# Altium schematic sheet ESP32-C3.SchDoc
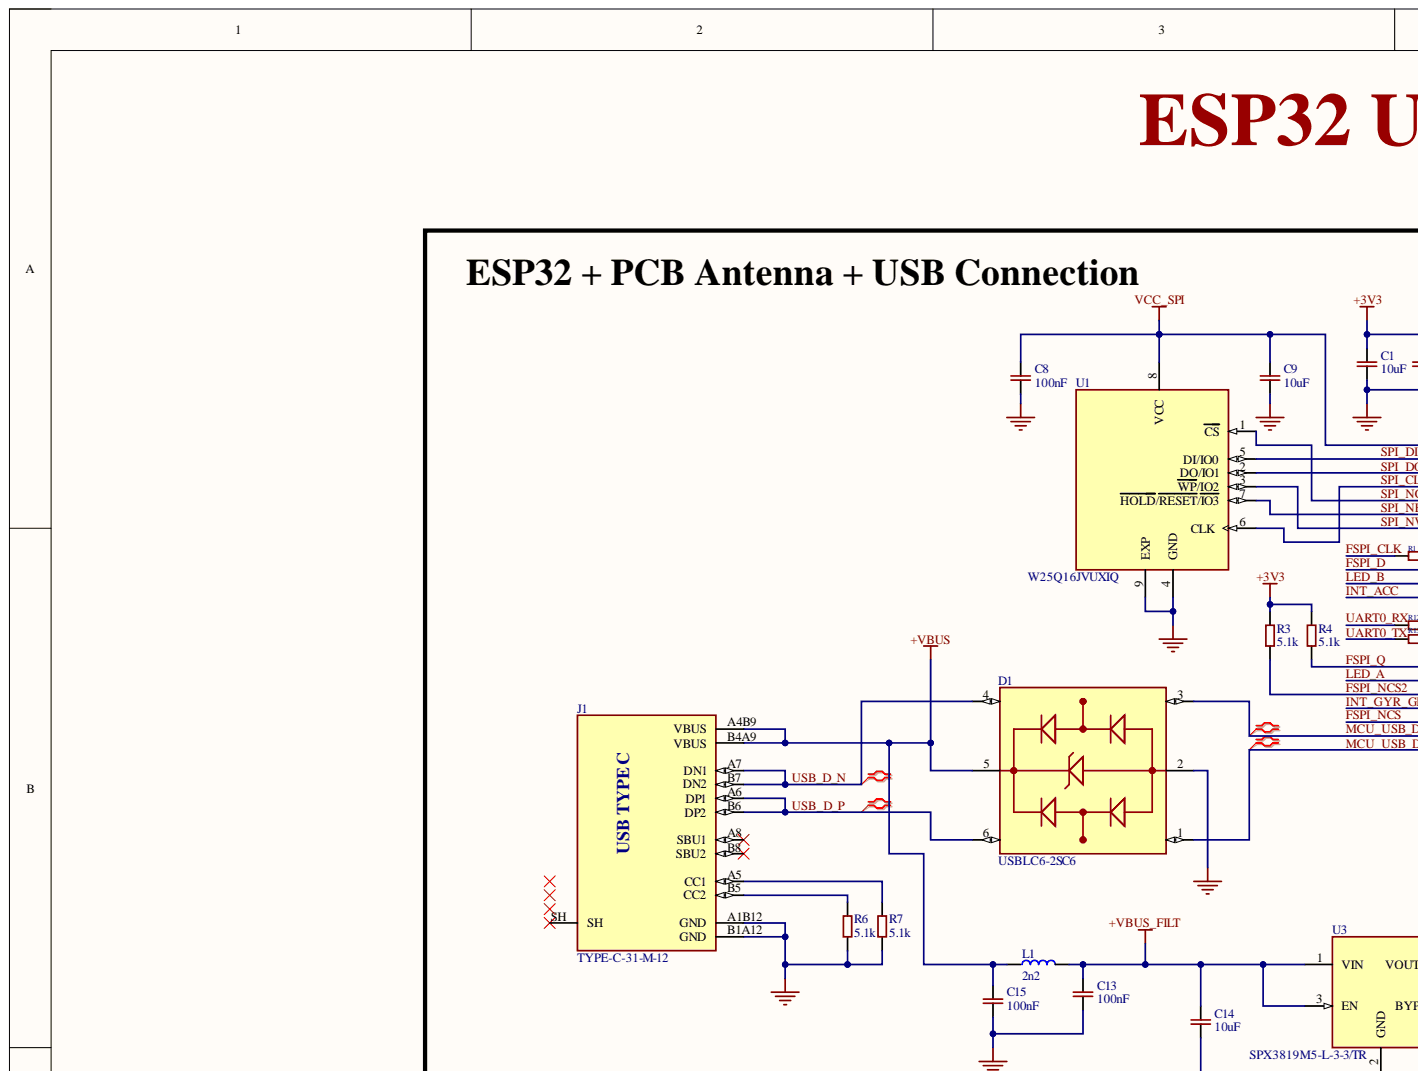
[diagram p0: ESP32-C3.SchDoc, top left]
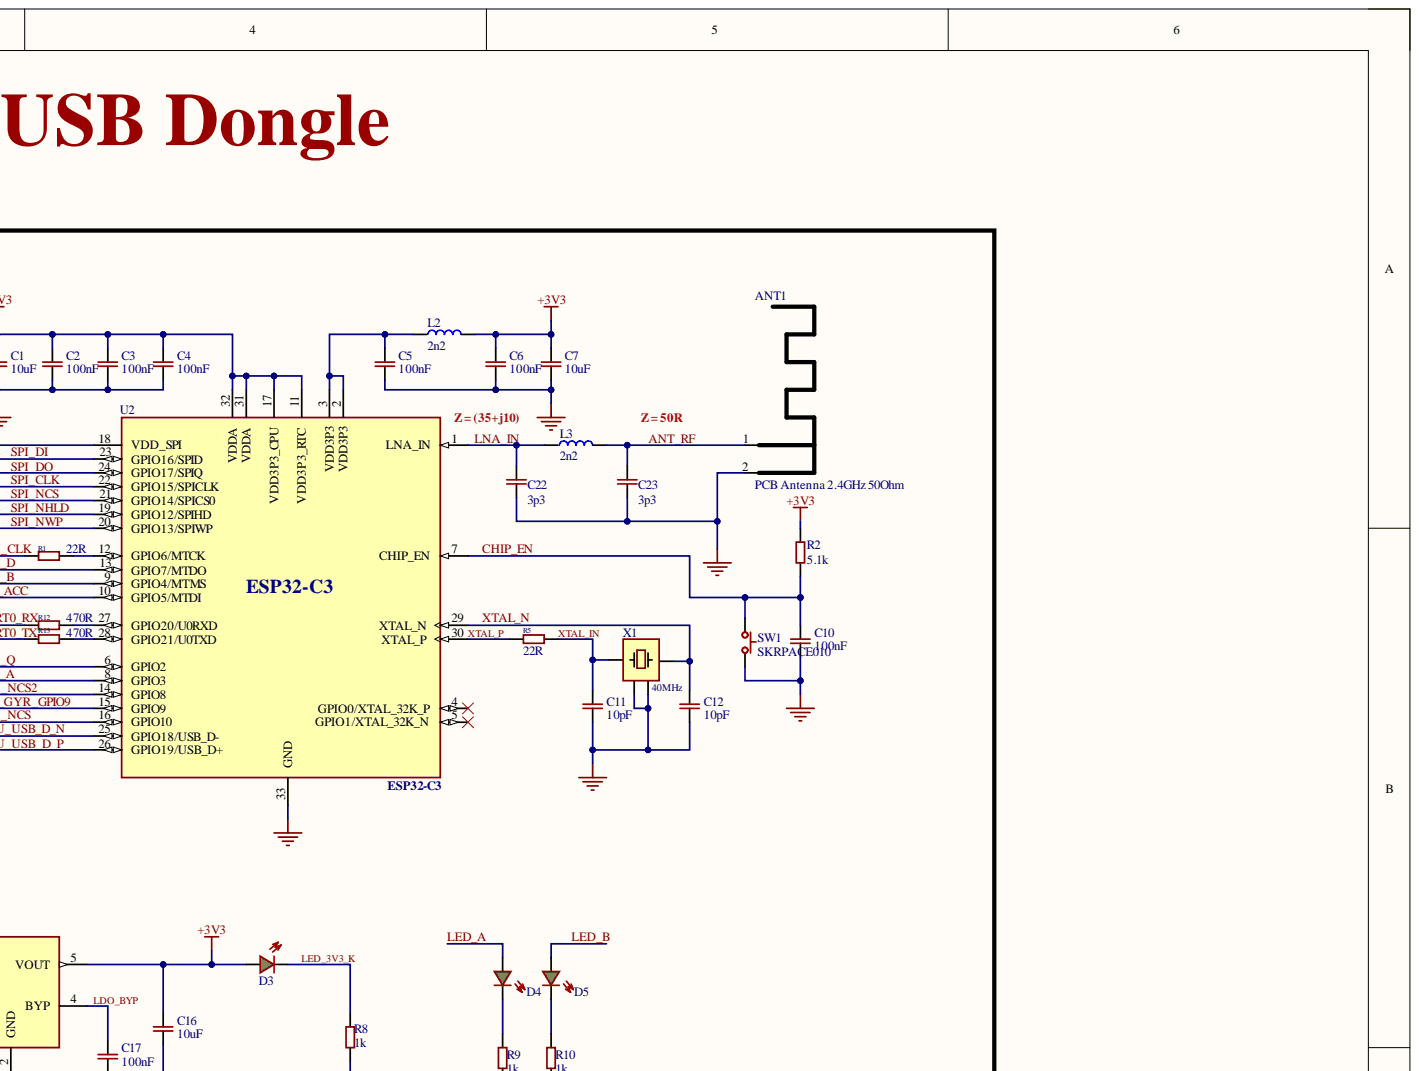
[diagram p1: ESP32-C3.SchDoc, top right]
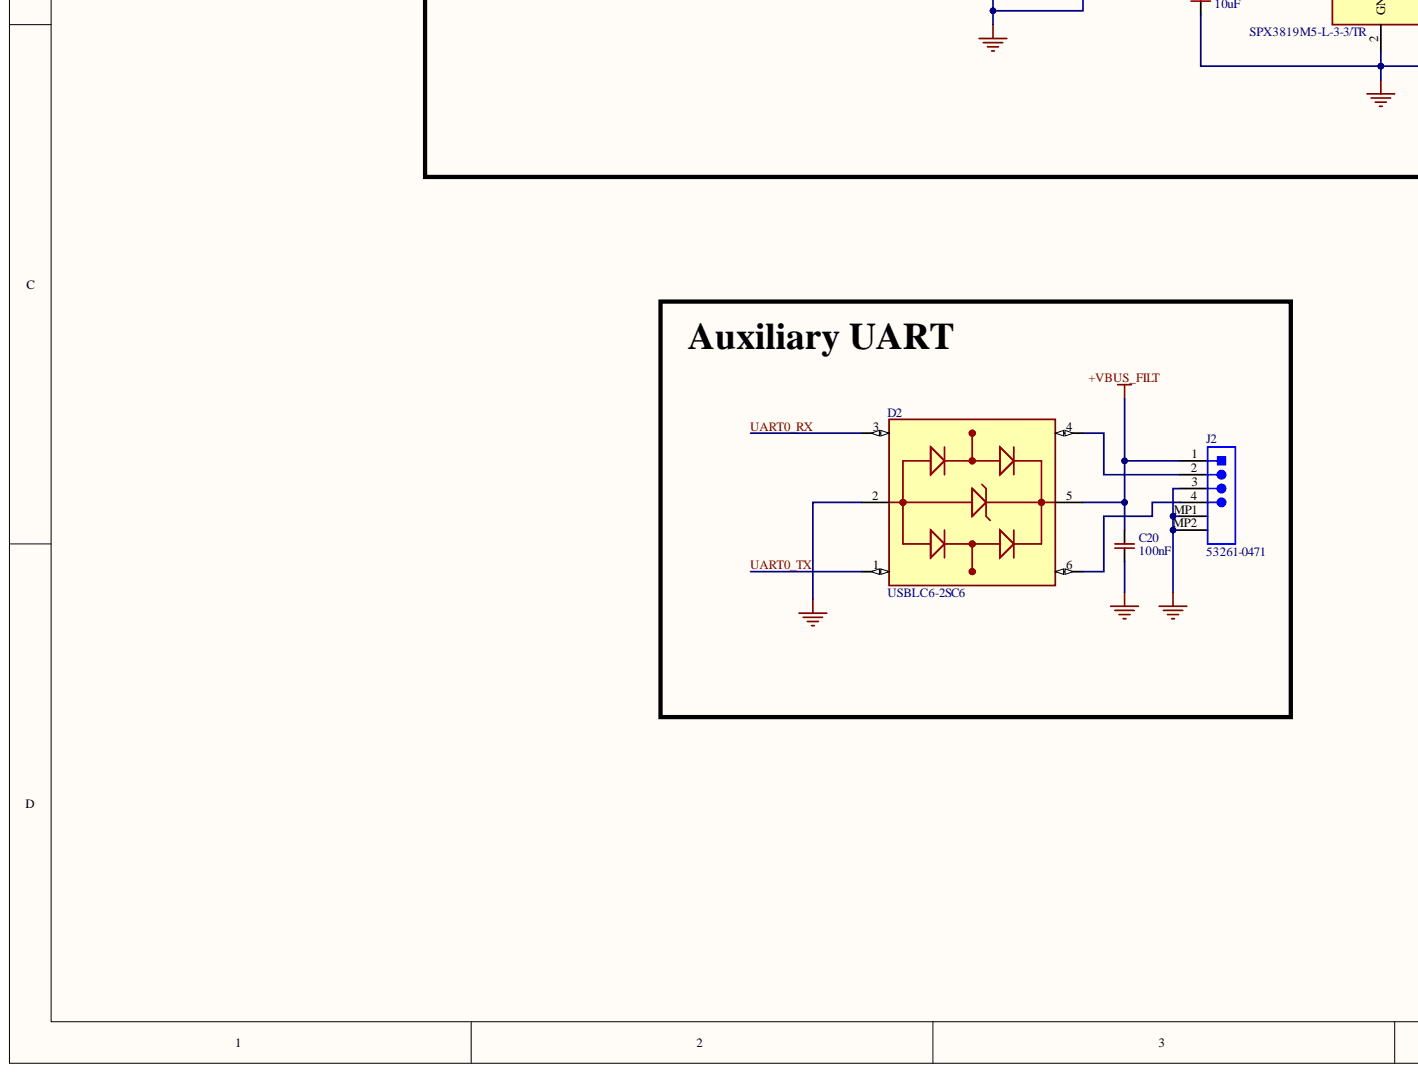
[diagram p2: ESP32-C3.SchDoc, bottom left]
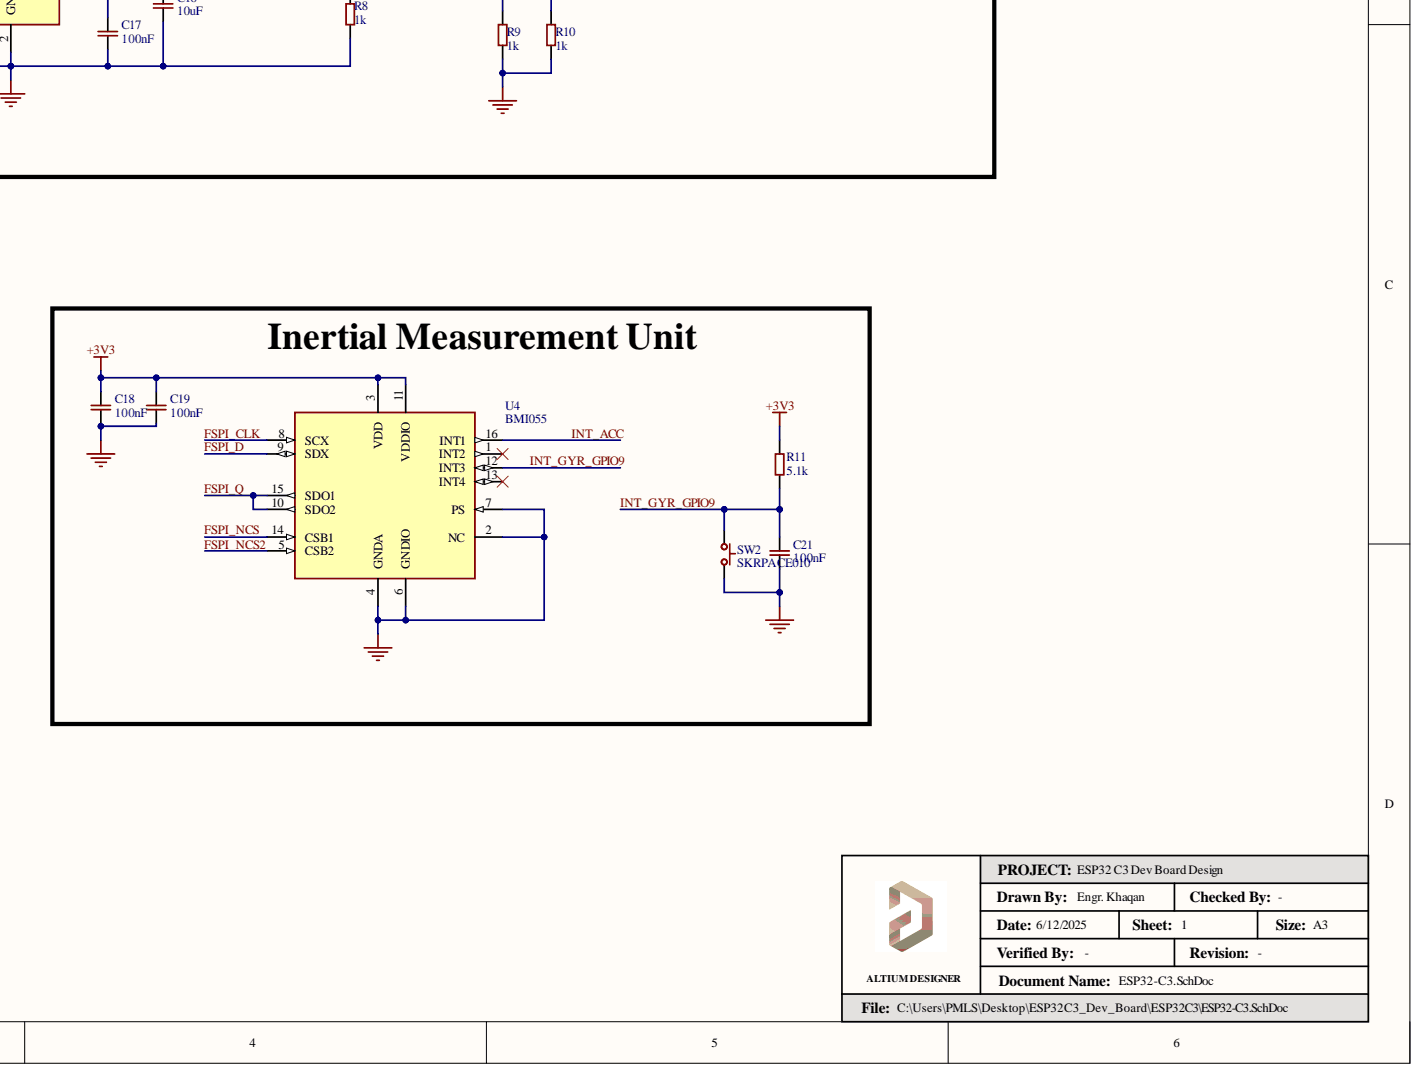
[diagram p3: ESP32-C3.SchDoc, bottom right]

## Parts
ANT1  PCB Antenna 2.4GHz 50Ohm  [ANT_IFA_USB_2_4GHz_50R]
C1  10uF  [CAP_0603]
C2  100nF  [CAP_0402]
C3  100nF  [CAP_0402]
C4  100nF  [CAP_0402]
C5  100nF  [CAP_0402]
C6  100nF  [CAP_0402]
C7  10uF  [CAP_0603]
C8  100nF  [CAP_0402]
C9  10uF  [CAP_0603]
C10  100nF  [CAP_0402]
C11  10pF  [CAP_0402]
C12  10pF  [CAP_0402]
C13  100nF  [CAP_0402]
C14  10uF  [CAP_0603]
C15  100nF  [CAP_0402]
C16  10uF  [CAP_0603]
C17  100nF  [CAP_0402]
C18  100nF  [CAP_0402]
C19  100nF  [CAP_0402]
C20  100nF  [CAP_0402]
C21  100nF  [CAP_0402]
C22  3p3  [CAP_0402]
C23  3p3  [CAP_0402]
D1  USBLC6-2SC6  [USBLC6-2SC6]
D2  USBLC6-2SC6  [USBLC6-2SC6]
D3  16-213/GHC-YR1S1/3T  [LED_0402_GREEN_SMD]  (value not drawn)
D4  16-213/GHC-YR1S1/3T  [LED_0402_GREEN_SMD]  (value not drawn)
D5  16-213/GHC-YR1S1/3T  [LED_0402_GREEN_SMD]  (value not drawn)
J1  TYPE-C-31-M-12  [TYPE-C-31-M-12]
J2  53261-0471  [MOLEX-53261-0471_V]
L1  2n2  [IND_SMD_0402]
L2  2n2  [IND_SMD_0402]
L3  2n2  [IND_SMD_0402]
R1  22R  [RES_0402]
R2  5.1k  [RES_0402]
R3  5.1k  [RES_0402]
R4  5.1k  [RES_0402]
R5  22R  [RES_0402]
R6  5.1k  [RES_0402]
R7  5.1k  [RES_0402]
R8  1k  [RES_0402]
R9  1k  [RES_0402]
R10  1k  [RES_0402]
R11  5.1k  [RES_0402]
R12  470R  [RES_0402]
R13  470R  [RES_0402]
SW1  SKRPACE010  [Tactile Switch]
SW2  SKRPACE010  [Tactile Switch]
U1  W25Q16JVUXIQ  [W25Q16JVUXIQ]
U2  ESP32-C3  [ESP32-C3]
U3  SPX3819M5-L-3-3/TR  [SPX3819M5-L-3-3/TR]
U4  BMI055  [BMI055]
X1  40MHz  [XTAL_40MHz_2520]

## Nets
+3V3: C1.2 C2.2 C3.2 C4.2 C6.2 C7.2 C16.2 C18.2 C19.2 D3.A L2.2 R2.2 R3.2 R4.2 R11.2 U2.11(VDD3P3_RTC) U2.17(VDD3P3_CPU) U2.31(VDDA) U2.32(VDDA) U3.5(VOUT) U4.3(VDD) U4.11(VDDIO)
+VBUS: C15.2 D1.5 J1.A4B9(VBUS) J1.B4A9(VBUS) L1.1
+VBUS_FILT: C13.2 C14.2 C20.2 D2.5 J2.1 L1.2 U3.1(VIN) U3.3(EN)
GND: ANT1.2 C1.1 C2.1 C3.1 C4.1 C5.1 C6.1 C7.1 C8.1 C9.1 C10.1 C11.1 C12.1 C13.1 C14.1 C15.1 C16.1 C17.1 C18.1 C19.1 C20.1 C21.1 C22.1 C23.1 D1.2 D2.2 J1.A1B12(GND) J1.B1A12(GND) J2.3 J2.MP1 J2.MP2 R6.1 R7.1 R8.1 R9.1 R10.1 SW1.34 SW2.34 U1.4(GND) U1.9(EXP) U2.33(GND) U3.2(GND) U4.2(NC) U4.4(GNDA) U4.6(GNDIO) U4.7(PS) X1.2 X1.4
VCC_SPI: C8.2 C9.2 U1.8(VCC) U2.18(VDD_SPI)
ANT_RF: ANT1.1 C23.2 L3.2
CHIP_EN: C10.2 R2.1 SW1.12 U2.7(CHIP_EN)
FSPI_CLK: R1.1 U4.8(SCX)
FSPI_D: U2.13(GPIO7/MTDO) U4.9(SDX)
FSPI_NCS: U2.16(GPIO10) U4.14(CSB1)
FSPI_NCS2: R3.1 U2.14(GPIO8) U4.5(CSB2)
FSPI_Q: R4.1 U2.6(GPIO2) U4.10(SDO2) U4.15(SDO1)
INT_ACC: U2.10(GPIO5/MTDI) U4.16(INT1)
INT_GYR_GPIO9: C21.2 R11.1 SW2.12 U2.15(GPIO9) U4.12(INT3)
LDO_BYP: C17.2 U3.4(BYP)
LED_3V3_K: D3.K R8.2
LED_A: D4.A U2.8(GPIO3)
LED_B: D5.A U2.9(GPIO4/MTMS)
LNA_IN: C22.2 L3.1 U2.1(LNA_IN)
MCU_USB_D_N: D1.3 U2.25(GPIO18/USB_D-)
MCU_USB_D_P: D1.1 U2.26(GPIO19/USB_D+)
SPI_CLK: U1.6(CLK) U2.22(GPIO15/SPICLK)
SPI_DI: U1.5(DI/IO0) U2.23(GPIO16/SPID)
SPI_DO: U1.2(DO/IO1) U2.24(GPIO17/SPIQ)
SPI_NCS: U1.1(CS) U2.21(GPIO14/SPICS0)
SPI_NHLD: U1.7(HOLD/RESET/IO3) U2.19(GPIO12/SPIHD)
SPI_NWP: U1.3(WP/IO2) U2.20(GPIO13/SPIWP)
UART0_RX: D2.3 R12.1
UART0_TX: D2.1 R13.1
USB_D_N: D1.4 J1.A7(DN1) J1.B7(DN2)
USB_D_P: D1.6 J1.A6(DP1) J1.B6(DP2)
XTAL_IN: C11.2 R5.2 X1.1
XTAL_N: C12.2 U2.29(XTAL_N) X1.3
XTAL_P: R5.1 U2.30(XTAL_P)
NetC5_2: C5.2 L2.1 U2.2(VDD3P3) U2.3(VDD3P3)
NetD2_4: D2.4 J2.2
NetD2_6: D2.6 J2.4
NetD4_K: D4.K R9.2
NetD5_K: D5.K R10.2
NetJ1_A5: J1.A5(CC1) R7.2
NetJ1_B5: J1.B5(CC2) R6.2
NetR1_2: R1.2 U2.12(GPIO6/MTCK)
NetR12_2: R12.2 U2.27(GPIO20/U0RXD)
NetR13_2: R13.2 U2.28(GPIO21/U0TXD)

Not connected: J1 A8(SBU1) B8(SBU2) SH; U2 4(GPIO0/XTAL_32K_P) 5(GPIO1/XTAL_32K_N); U4 1(INT2) 13(INT4)

## Library data of the parts
ANT1 = ANT_IFA_USB_2_4GHz_50R: Inverted F 2.4GHz ISM PCB Antenna 50Ohm; Component Kind: Standard; Library Name: Schlib_ESP32C3.SchLib
C1 C7 C9 C14 C16 = CAP_0603: Ceramic Capacitor, Multilayer, Ceramic, 10V, 10% +Tol, 10% -Tol, X5R, +-15% TC, 10uF, Surface Mount, 0603 inch; Manufacturer: Samsung Electro-Mechanics; Manufacturer Part Number: CL10A106KP8NNNC; Value: 10µF; Case Code (Imperial): 0603; Case Code (Metric): 1608; Case/Package: 0603; Category: Ceramic Capacitors; China RoHS: Compliant; Component Kind: Standard; ComponentLink1Description: https://datasheet.octopart.com:443/CL10A106KP8NNNC-Samsung-Electro-Mechanics-datasheet-181960519.pdf?src-supplier=Newark; ComponentLink1URL: https://datasheet.octopart.com:443/CL10A106KP8NNNC-Samsung-Electro-Mechanics-datasheet-181960519.pdf?src-supplier=Newark; ComponentLink2Description: https://datasheet.octopart.com/CL10A106KP8NNNC-Samsung-Electro-Mechanics-datasheet-8324245.pdf; ComponentLink2URL: https://datasheet.octopart.com/CL10A106KP8NNNC-Samsung-Electro-Mechanics-datasheet-8324245.pdf; ComponentLink3Description: https://datasheet.octopart.com/CL10A106KP8NNNC-Samsung-Electro-Mechanics-datasheet-97040617.pdf; ComponentLink3URL: https://datasheet.octopart.com/CL10A106KP8NNNC-Samsung-Electro-Mechanics-datasheet-97040617.pdf; ComponentLink4Description: https://datasheet.octopart.com:443/CL10A106KP8NNNC-Samsung-Electro-Mechanics-datasheet-136031754.pdf?src-supplier=Future+Electronics; ComponentLink4URL: https://datasheet.octopart.com:443/CL10A106KP8NNNC-Samsung-Electro-Mechanics-datasheet-136031754.pdf?src-supplier=Future+Electronics; ComponentLink5Description: https://datasheet.octopart.com:443/CL10A106KP8NNNC-Samsung-Electro-Mechanics-datasheet-110226289.pdf?src-supplier=Future+Electronics; ComponentLink5URL: https://datasheet.octopart.com:443/CL10A106KP8NNNC-Samsung-Electro-Mechanics-datasheet-110226289.pdf?src-supplier=Future+Electronics; ComponentLink6Description: https://datasheet.octopart.com:443/CL10A106KP8NNNC-Samsung-Electro-Mechanics-datasheet-81461787.pdf?src-supplier=Future+Electronics; ComponentLink6URL: https://datasheet.octopart.com:443/CL10A106KP8NNNC-Samsung-Electro-Mechanics-datasheet-81461787.pdf?src-supplier=Future+Electronics; ComponentLink7Description: https://datasheet.octopart.com:443/CL10A106KP8NNNC-Samsung-datasheet-48647232.pdf?src-supplier=Future+Electronics; ComponentLink7URL: https://datasheet.octopart.com:443/CL10A106KP8NNNC-Samsung-datasheet-48647232.pdf?src-supplier=Future+Electronics; ComponentLink8Description: https://datasheet.octopart.com:443/CL10A106KP8NNNC-Samsung-datasheet-34157262.pdf?src-supplier=Future+Electronics; ComponentLink8URL: https://datasheet.octopart.com:443/CL10A106KP8NNNC-Samsung-datasheet-34157262.pdf?src-supplier=Future+Electronics; ComponentLink9Description: https://datasheet.octopart.com:443/CL10A106KP8NNNC-Samsung-datasheet-26589120.pdf?src-supplier=Future+Electronics; ComponentLink9URL: https://datasheet.octopart.com:443/CL10A106KP8NNNC-Samsung-datasheet-26589120.pdf?src-supplier=Future+Electronics; ComponentLink10Description: https://datasheet.octopart.com:443/CL10A106KP8NNNC-Samsung-Electro-Mechanics-datasheet-149156481.pdf?src-supplier=Future+Electronics; ComponentLink10URL: https://datasheet.octopart.com:443/CL10A106KP8NNNC-Samsung-Electro-Mechanics-datasheet-149156481.pdf?src-supplier=Future+Electronics; ComponentLink11Description: https://datasheet.octopart.com:443/CL10A106KP8NNNC-Samsung-Electro-Mechanics-datasheet-11968064.pdf?src-supplier=Arrow+Electronics; ComponentLink11URL: https://datasheet.octopart.com:443/CL10A106KP8NNNC-Samsung-Electro-Mechanics-datasheet-11968064.pdf?src-supplier=Arrow+Electronics; ComponentLink12Description: https://datasheet.octopart.com:443/CL10A106KP8NNNC-Samsung-datasheet-8326407.pdf?src-supplier=TME; ComponentLink12URL: https://datasheet.octopart.com:443/CL10A106KP8NNNC-Samsung-datasheet-8326407.pdf?src-supplier=TME; Contact Plating: Tin; Depth: 800µm; Dielectric: X5R; Dielectric Material: Ceramic; Height: 800µm; LCSC: C19702; Lead Free: Lead Free; Length: 1.6mm; Library Name: Schlib_ESP32C3.SchLib; Lifecycle Status: Production; Material: Ceramic; Max Operating Temperature: 85°C; Min Operating Temperature: -55°C; Mounting Technology: Surface Mount; Number of Terminals: 2; Pricing: 1=0.08, 10=0.027 (USD); Radiation Hardening: No; REACH SVHC: Yes; RoHS: Compliant; Schedule B: 8532240020, 8532240020|8532240020|8532240020|8532240020|8532240020|8532240020|8532240020|8532240020|8532240020|8532240020|8532240020|8532240020|8532240020|8532240020|8532240020|8532240020|8532240020|8532240020|8532240020|8532240020|8532240020|8532240020|8532240020|85; Stock: 3199651; Supplier: DigiKey; Supplier Part Number: 1276-1192-1-ND; Thickness: 889µm; Tolerance: 10%; Voltage Rating: 10V; Voltage Rating (DC): 10V; Width: 800µm
C2-C6 C8 C10 C13 C15 C17-C21 = CAP_0402: Ceramic Capacitor, Multilayer, Ceramic, 16V, 10% +Tol, 10% -Tol, X7R, +-15% TC, 100nF, Surface Mount, 0402 inch; Manufacturer: Samsung Electro-Mechanics; Manufacturer Part Number: CL05B104KO5NNNC; Case Code (Imperial): 0402; Case Code (Metric): 1005; Case/Package: 0402; Category: Ceramic Capacitors; China RoHS: Compliant; Component Kind: Standard; ComponentLink1Description: https://datasheet.octopart.com:443/CL05B104KO5NNNC-Samsung-Electro-Mechanics-datasheet-181960518.pdf?src-supplier=IHS; ComponentLink1URL: https://datasheet.octopart.com:443/CL05B104KO5NNNC-Samsung-Electro-Mechanics-datasheet-181960518.pdf?src-supplier=IHS; ComponentLink2Description: https://datasheet.octopart.com:443/CL05B104KO5NNNC-Samsung-Electro-Mechanics-datasheet-81461623.pdf?src-supplier=Upverter; ComponentLink2URL: https://datasheet.octopart.com:443/CL05B104KO5NNNC-Samsung-Electro-Mechanics-datasheet-81461623.pdf?src-supplier=Upverter; ComponentLink3Description: https://datasheet.octopart.com/CL05B104KO5NNNC-Samsung-Electro-Mechanics-datasheet-8324245.pdf; ComponentLink3URL: https://datasheet.octopart.com/CL05B104KO5NNNC-Samsung-Electro-Mechanics-datasheet-8324245.pdf; ComponentLink4Description: https://datasheet.octopart.com/CL05B104KO5NNNC-Samsung-Electro-Mechanics-datasheet-97040617.pdf; ComponentLink4URL: https://datasheet.octopart.com/CL05B104KO5NNNC-Samsung-Electro-Mechanics-datasheet-97040617.pdf; ComponentLink5Description: https://datasheet.octopart.com:443/CL05B104KO5NNNC-Samsung-Electro-Mechanics-datasheet-141346863.pdf?src-supplier=Samsung+SCT; ComponentLink5URL: https://datasheet.octopart.com:443/CL05B104KO5NNNC-Samsung-Electro-Mechanics-datasheet-141346863.pdf?src-supplier=Samsung+SCT; ComponentLink6Description: https://datasheet.octopart.com:443/CL05B104KO5NNNC-Samsung-datasheet-151956582.pdf?src-supplier=SOS+electronic; ComponentLink6URL: https://datasheet.octopart.com:443/CL05B104KO5NNNC-Samsung-datasheet-151956582.pdf?src-supplier=SOS+electronic; ComponentLink7Description: https://datasheet.octopart.com:443/CL05B104KO5NNNC-Samsung-datasheet-42485123.pdf?src-supplier=Future+Electronics; ComponentLink7URL: https://datasheet.octopart.com:443/CL05B104KO5NNNC-Samsung-datasheet-42485123.pdf?src-supplier=Future+Electronics; ComponentLink8Description: https://datasheet.octopart.com:443/CL05B104KO5NNNC-Samsung-datasheet-34153835.pdf?src-supplier=Future+Electronics; ComponentLink8URL: https://datasheet.octopart.com:443/CL05B104KO5NNNC-Samsung-datasheet-34153835.pdf?src-supplier=Future+Electronics; ComponentLink9Description: https://datasheet.octopart.com:443/CL05B104KO5NNNC-Samsung-datasheet-26589024.pdf?src-supplier=Future+Electronics; ComponentLink9URL: https://datasheet.octopart.com:443/CL05B104KO5NNNC-Samsung-datasheet-26589024.pdf?src-supplier=Future+Electronics; ComponentLink10Description: https://datasheet.octopart.com:443/CL05B104KO5NNNC-Samsung-Electro-Mechanics-datasheet-149136714.pdf?src-supplier=Future+Electronics; ComponentLink10URL: https://datasheet.octopart.com:443/CL05B104KO5NNNC-Samsung-Electro-Mechanics-datasheet-149136714.pdf?src-supplier=Future+Electronics; ComponentLink11Description: https://datasheet.octopart.com:443/CL05B104KO5NNNC-Samsung-datasheet-59220082.pdf?src-supplier=TME; ComponentLink11URL: https://datasheet.octopart.com:443/CL05B104KO5NNNC-Samsung-datasheet-59220082.pdf?src-supplier=TME; Contact Plating: Tin; Depth: 500µm; Dielectric: X7R; Dielectric Material: Ceramic; Height: 500µm; LCSC: C1525; Lead Free: Lead Free; Length: 1mm; Library Name: Schlib_ESP32C3.SchLib; Lifecycle Status: Production; Material: Ceramic; Max Operating Temperature: 125°C; Min Operating Temperature: -55°C; Mounting Technology: Surface Mount; Number of Terminals: 2; Pricing: 1=0.08, 10=0.006, 50=0.006, 100=0.0056, 500=0.0048, 1000=0.0032 (USD); Radiation Hardening: No; REACH SVHC: Yes; RoHS: Compliant; Schedule B: 8532240020, 8532240020|8532240020|8532240020|8532240020|8532240020|8532240020|8532240020|8532240020|8532240020|8532240020|8532240020|8532240020|8532240020|8532240020|8532240020|8532240020|8532240020|8532240020|8532240020|8532240020|8532240020|8532240020|8532240020|85; Stock: 9075; Supplier: DigiKey; Supplier Part Number: 1276-1001-1-ND; Thickness: 558.8µm; Tolerance: 10%; Value: 100nF; Voltage: 16V; Voltage Rating: 16V; Voltage Rating (DC): 16V; Width: 500µm
C11 C12 = CAP_0402: Ceramic Capacitor, Multilayer, Ceramic, 50V, 5% +Tol, 5% -Tol, C0G, 0+-30ppm/Celcius TC, 10pF, Surface Mount, 0402 inch; Manufacturer: Samsung Electro-Mechanics; Manufacturer Part Number: CL05C100JB5NNNC; Temperature Coefficient: 30ppm/°C; Case Code (Imperial): 0402; Case Code (Metric): 1005; Case/Package: 0402; Category: Ceramic Capacitors; China RoHS: Compliant; Component Kind: Standard; ComponentLink1Description: https://datasheet.octopart.com:443/CL05C100JB5NNNC-Samsung-Electro-Mechanics-datasheet-136482242.pdf?src-supplier=IHS; ComponentLink1URL: https://datasheet.octopart.com:443/CL05C100JB5NNNC-Samsung-Electro-Mechanics-datasheet-136482242.pdf?src-supplier=IHS; ComponentLink2Description: https://datasheet.octopart.com:443/CL05C100JB5NNNC-Samsung-Electro-Mechanics-datasheet-11758155.pdf?src-supplier=Future+Electronics; ComponentLink2URL: https://datasheet.octopart.com:443/CL05C100JB5NNNC-Samsung-Electro-Mechanics-datasheet-11758155.pdf?src-supplier=Future+Electronics; ComponentLink3Description: https://datasheet.octopart.com:443/CL05C100JB5NNNC-Samsung-Electro-Mechanics-datasheet-110226242.pdf?src-supplier=Future+Electronics; ComponentLink3URL: https://datasheet.octopart.com:443/CL05C100JB5NNNC-Samsung-Electro-Mechanics-datasheet-110226242.pdf?src-supplier=Future+Electronics; ComponentLink4Description: https://datasheet.octopart.com:443/CL05C100JB5NNNC-Samsung-Electro-Mechanics-datasheet-81461703.pdf?src-supplier=Future+Electronics; ComponentLink4URL: https://datasheet.octopart.com:443/CL05C100JB5NNNC-Samsung-Electro-Mechanics-datasheet-81461703.pdf?src-supplier=Future+Electronics; ComponentLink5Description: https://datasheet.octopart.com:443/CL05C100JB5NNNC-Samsung-datasheet-12525757.pdf?src-supplier=Future+Electronics; ComponentLink5URL: https://datasheet.octopart.com:443/CL05C100JB5NNNC-Samsung-datasheet-12525757.pdf?src-supplier=Future+Electronics; ComponentLink6Description: https://datasheet.octopart.com:443/CL05C100JB5NNNC-Samsung-Electro-Mechanics-datasheet-149136756.pdf?src-supplier=Future+Electronics; ComponentLink6URL: https://datasheet.octopart.com:443/CL05C100JB5NNNC-Samsung-Electro-Mechanics-datasheet-149136756.pdf?src-supplier=Future+Electronics; ComponentLink7Description: https://datasheet.octopart.com:443/CL05C100JB5NNNC-Samsung-datasheet-37081328.pdf?src-supplier=Future+Electronics; ComponentLink7URL: https://datasheet.octopart.com:443/CL05C100JB5NNNC-Samsung-datasheet-37081328.pdf?src-supplier=Future+Electronics; ComponentLink8Description: https://datasheet.octopart.com/CL05C100JB5NNNC-Samsung-Electro-Mechanics-datasheet-97040617.pdf; ComponentLink8URL: https://datasheet.octopart.com/CL05C100JB5NNNC-Samsung-Electro-Mechanics-datasheet-97040617.pdf; ComponentLink9Description: https://datasheet.octopart.com/CL05C100JB5NNNC-Samsung-Electro-Mechanics-datasheet-8324245.pdf; ComponentLink9URL: https://datasheet.octopart.com/CL05C100JB5NNNC-Samsung-Electro-Mechanics-datasheet-8324245.pdf; ComponentLink10Description: https://datasheet.octopart.com:443/CL05C100JB5NNNC-Samsung-datasheet-59220082.pdf?src-supplier=TME; ComponentLink10URL: https://datasheet.octopart.com:443/CL05C100JB5NNNC-Samsung-datasheet-59220082.pdf?src-supplier=TME; Contact Plating: Tin; Depth: 500µm; Dielectric: C0G; Dielectric Material: Ceramic; Height: 550µm; LCSC: C32949; Length: 1mm; Library Name: Schlib_ESP32C3.SchLib; Lifecycle Status: Production; Material: Ceramic; Max Operating Temperature: 125°C; Min Operating Temperature: -55°C; Mounting Technology: Surface Mount; Number of Terminals: 2; Pricing: 1=0.08, 10=0.006, 50=0.0048 (USD); Radiation Hardening: No; REACH SVHC: Yes; RoHS: Compliant; Schedule B: 8532240020, 8532240020|8532240020, 8532240020|8532240020|8532240020, 8532240020|8532240020|8532240020|8532240020; Stock: 68764; Supplier: DigiKey; Supplier Part Number: 1276-1139-1-ND; Thickness: 558.8µm; Tolerance: 5%; Value: 10pF; Voltage Rating: 50V; Voltage Rating (DC): 50V; Width: 500µm
C22 C23 = CAP_0402: 3.3pF 50V Ceramic Capacitor C0G 0402; Manufacturer: FH; Manufacturer Part Number: 0402CG3R3C500NT; Component Kind: Standard; LCSC: C1565; Library Name: Schlib_ESP32C3.SchLib
D1 D2 = USBLC6-2SC6: STMicroelectronics USBLC6-2SC6 TVS diode surge protection on full tape reel; Breakdown Voltage: 6V; Clamping Voltage: 17V; Data Rate: 480Mbps; Direction: Unidirectional; Element Configuration: Dual; ESD Protection: Yes; Forward Voltage: 1.1V; Leakage Current: 150nA; Max Junction Temperature (Tj): 125°C; Max Repetitive Reverse Voltage (Vrrm): 5.25V; Number of Bidirectional Channels: 2; Number of Elements: 1; Peak Pulse Current: 5A; Reverse Standoff Voltage: 5V; Test Current: 1mA; Value: 2.5pF; Case/Package: SOT-23; Category: TVS Diodes; China RoHS: Compliant; Component Kind: Standard; ComponentLink1Description: https://datasheet.octopart.com:443/USBLC6-2SC6-STMicroelectronics-datasheet-10412581.pdf?src-supplier=Newark; ComponentLink1URL: https://datasheet.octopart.com:443/USBLC6-2SC6-STMicroelectronics-datasheet-10412581.pdf?src-supplier=Newark; ComponentLink2Description: https://datasheet.octopart.com:443/USBLC6-2SC6-STMicroelectronics-datasheet-181961833.pdf?src-supplier=Newark; ComponentLink2URL: https://datasheet.octopart.com:443/USBLC6-2SC6-STMicroelectronics-datasheet-181961833.pdf?src-supplier=Newark; ComponentLink3Description: https://datasheet.octopart.com:443/USBLC6-2SC6-STMicroelectronics-datasheet-525477.pdf?src-supplier=Factory+Futures; ComponentLink3URL: https://datasheet.octopart.com:443/USBLC6-2SC6-STMicroelectronics-datasheet-525477.pdf?src-supplier=Factory+Futures; ComponentLink4Description: https://datasheet.octopart.com:443/USBLC6-2SC6-STMicroelectronics-datasheet-82998616.pdf?src-supplier=Farnell; ComponentLink4URL: https://datasheet.octopart.com:443/USBLC6-2SC6-STMicroelectronics-datasheet-82998616.pdf?src-supplier=Farnell; ComponentLink5Description: https://datasheet.octopart.com:443/USBLC6-2SC6-STMicroelectronics-datasheet-46285.pdf?src-supplier=Farnell; ComponentLink5URL: https://datasheet.octopart.com:443/USBLC6-2SC6-STMicroelectronics-datasheet-46285.pdf?src-supplier=Farnell; ComponentLink6Description: https://datasheet.octopart.com:443/USBLC6-2SC6-STMicroelectronics-datasheet-14050643.pdf?src-supplier=iiiC; ComponentLink6URL: https://datasheet.octopart.com:443/USBLC6-2SC6-STMicroelectronics-datasheet-14050643.pdf?src-supplier=iiiC; ComponentLink7Description: https://datasheet.octopart.com:443/USBLC6-2SC6-STMicroelectronics-datasheet-10521292.pdf?src-supplier=Jameco; ComponentLink7URL: https://datasheet.octopart.com:443/USBLC6-2SC6-STMicroelectronics-datasheet-10521292.pdf?src-supplier=Jameco; Contact Plating: Tin; Depth: 1.75mm; Height: 1.45mm; LCSC: C7519; Lead Free: Lead Free; Length: 3.05mm; Library Name: Schlib_ESP32C3.SchLib; Lifecycle Status: Production; Manufacturer: STMicroelectronics; Manufacturer Part Number: USBLC6-2SC6; Max Breakdown Voltage: 6V; Max Operating Temperature: 125°C; Max Reverse Leakage Current: 150nA; Min Breakdown Voltage: 6V; Min Operating Temperature: -40°C; Mounting Technology: Surface Mount; Number of Channels: 2; Number of Terminals: 6; Operating Supply Voltage: 1.1V; Peak Reverse Current: 150nA; Pins: 6; Polarity: Unidirectional; Power Line Protection: Yes; Pricing: 1=0.36, 10=0.243, 100=0.163, 500=0.127, 1000=0.114 (USD); Radiation Hardening: No; REACH SVHC: Yes; Reverse Breakdown Voltage: 6V; RoHS: Compliant; Schedule B: 8536300000, 8536300000|8536300000|8536300000|8536300000|8536300000|8536300000|8536300000|8536300000|8536300000|8536300000|8536300000|8536300000|8536300000|8536300000|8536300000|8536300000|8536300000|8536300000|8536300000|8536300000|8536300000|8536300000|8536300000|85; Stock: 156375; Supplier: DigiKey; Supplier Part Number: 497-5235-1-ND; Termination: SMD/SMT; Width: 1.75mm; Working Voltage: 5V
D3-D5 = LED_0402_GREEN_SMD: Emerald Green 518nm LED Indication - Discrete 0402; Manufacturer: EVERLIGHT; Component Kind: Standard; LCSC: C74338; Library Name: Schlib_ESP32C3.SchLib; Manufacturer Part Number: 16-213/GHC-YR1S1/3T
J1 = TYPE-C-31-M-12: USB-C (USB TYPE-C) Receptacle Connector 16 Position Surface Mount; Manufacturer: Korean Hroparts Elec; Component Kind: Standard; LCSC: C165948; Library Name: Schlib_ESP32C3.SchLib; Manufacturer Part Number: TYPE-C-31-M-12
J2 = CMP-2000-05238-1: Male Header, Pitch 1.25 mm, 1 x 4 Position, Height 3.4 mm, -40 to 85 degC, RoHS, Tape and Reel; Manufacturer: MOLEX; Manufacturer Part Number: 532610471; Component Kind: Standard; LCSC: C240847; Library Name: Schlib_ESP32C3.SchLib; Supplier 1: DigiKey; Supplier Part Number 1: WM7622CT-ND
L1-L3 = IND_SMD_0402: Manufacturer: muRata; Manufacturer Part Number: LQW15AN2N2G80D; Component Kind: Standard; Description: IND_SMD_0402; LCSC: C412264; Library Name: Schlib_ESP32C3.SchLib
R1 R5 = RES_0402: 22Ω 62.5mW 50V ±100ppm/℃ Thick Film Resistor ±1% 0402 Chip Resistor - Surface Mount RoHS; Manufacturer: UNI-ROYAL; Manufacturer Part Number: 0402WGF220JTCE; Component Kind: Standard; LCSC: C25092; Library Name: Schlib_ESP32C3.SchLib
R2-R4 R11 = RES_0402: 5.1kΩ 62.5mW 50V ±100ppm/℃ Thick Film Resistor ±1% 0402 Chip Resistor - Surface Mount RoHS; Manufacturer: UNI-ROYAL; Manufacturer Part Number: 0402WGF5101TCE; Component Kind: Standard; LCSC: C25905; Library Name: Schlib_ESP32C3.SchLib
R6 R7 = RES_0402: 5.1 kOhms ±5% 0.063W, 1/16W Chip Resistor 0402 (1005 Metric) Moisture Resistant Thick Film; Manufacturer: YAGEO; Manufacturer Part Number: RC0402JR-075K1L; Component Kind: Standard; LCSC: C105873; Library Name: Schlib_ESP32C3.SchLib
R8-R10 = RES_0402: 1kΩ ±1% 62.5mW 0402 Thick Film Resistor; Manufacturer: UNI-ROYAL; Manufacturer Part Number: 0402WGF1001TCE; Component Kind: Standard; LCSC: C11702; Library Name: Schlib_ESP32C3.SchLib
R12 R13 = RES_0402: 470Ω ±1% 62.5mW 0402 Thick Film Resistor; Manufacturer: UNI-ROYAL; Manufacturer Part Number: 0402WGF4700TCE; Component Kind: Standard; LCSC: C25117; Library Name: Schlib_ESP32C3.SchLib
SW1 SW2 = Tactile Switch: Switch Tactile N.O. SPST Button J-Bend 0.05A 16VDC 2.55N SMD T/R; Manufacturer: ALPS; Actuator Length: 700µm; Contact Current Rating: 50mA; Contact Resistance: 500mΩ; Electrical Life: 50kcycles; Insulation Resistance: 100MΩ; Max Voltage Rating (DC): 16V; Number of Positions: 1; Case/Package: SMD/SMT; Category: Tactile Switches; Color: Natural; Component Kind: Standard; ComponentLink1Description: https://datasheet.octopart.com:443/SKRPACE010-ALPS-datasheet-182234810.pdf?src-supplier=IHS; ComponentLink1URL: https://datasheet.octopart.com:443/SKRPACE010-ALPS-datasheet-182234810.pdf?src-supplier=IHS; ComponentLink2Description: https://datasheet.octopart.com:443/SKRPACE010-ALPS-datasheet-182235267.pdf?src-supplier=IHS; ComponentLink2URL: https://datasheet.octopart.com:443/SKRPACE010-ALPS-datasheet-182235267.pdf?src-supplier=IHS; ComponentLink3Description: https://datasheet.octopart.com:443/SKRPACE010-ALPS-datasheet-41327040.pdf?src-supplier=Master+Electronics; ComponentLink3URL: https://datasheet.octopart.com:443/SKRPACE010-ALPS-datasheet-41327040.pdf?src-supplier=Master+Electronics; ComponentLink4Description: https://datasheet.octopart.com:443/SKRPACE010-ALPS-datasheet-138899522.pdf?src-supplier=TME; ComponentLink4URL: https://datasheet.octopart.com:443/SKRPACE010-ALPS-datasheet-138899522.pdf?src-supplier=TME; ComponentLink5Description: https://datasheet.octopart.com:443/SKRPACE010-ALPS-datasheet-142045378.pdf?src-supplier=Arrow.cn; ComponentLink5URL: https://datasheet.octopart.com:443/SKRPACE010-ALPS-datasheet-142045378.pdf?src-supplier=Arrow.cn; ComponentLink6Description: https://datasheet.octopart.com:443/SKRPACE010-ALPS-datasheet-37145204.pdf?src-supplier=Electro+Sonic; ComponentLink6URL: https://datasheet.octopart.com:443/SKRPACE010-ALPS-datasheet-37145204.pdf?src-supplier=Electro+Sonic; Contact Plating: Silver; Current Rating: 50mA; Depth: 3.2mm; Height: 1.6mm; Lead Free: Lead Free; Length: 4.2mm; Library Name: Schlib_ESP32C3.SchLib; Life (Cycles): 50kcycles; Lifecycle Status: Production; Manufacturer Part Number: SKRPACE010; Max Current Rating: 50mA; Max Operating Temperature: 85°C; Min Operating Temperature: -30°C; Mounting Technology: Surface Mount; Operating Force: 2.55N; Orientation: Straight; Packaging: Tape and Reel; Pricing: 1=0.21, 10=0.179, 25=0.1684, 100=0.1511, 250=0.14016, 500=0.13228, 1000=0.12484 (USD); Radiation Hardening: No; REACH SVHC: Yes; RoHS: Compliant; Schedule B: 8536509065; Supplier: DigiKey; Supplier Part Number: 4809-SKRPACE010DKR-ND; Termination: J-Bend; Throw Configuration: SPST; Voltage Rating (DC): 16V
U1 = W25Q16JVUXIQ: NOR Flash Serial (SPI, Dual SPI, Quad SPI) 3V/3.3V 16M-bit 2M x 8 6ns 8-Pin USON EP T/R; Manufacturer: Winbond; Manufacturer Part Number: W25Q16JVUXIQ TR; Category: Memory; Component Kind: Standard; ComponentLink1Description: https://datasheet.octopart.com:443/W25Q16JVUXIQ-TR-Winbond-datasheet-165882617.pdf?src-supplier=ODG+(Origin+Data+Global); ComponentLink1URL: https://datasheet.octopart.com:443/W25Q16JVUXIQ-TR-Winbond-datasheet-165882617.pdf?src-supplier=ODG+(Origin+Data+Global); LCSC: C2843335; Library Name: Schlib_ESP32C3.SchLib; Pricing: 4000=0.49661, 8000=0.48422, 12000=0.47705, 20000=0.46812 (USD); Schedule B: 8542320070, 8542320070|8542320070|8542320070|8542320070|8542320070; Stock: 16000; Supplier: DigiKey; Supplier Part Number: 256-W25Q16JVUXIQTR-ND
U2 = ESP32-C3: 400KB 22 3V~3.6V RISC-V 160MHz QFN-32-EP(5x5) Microcontroller Units (MCUs/MPUs/SOCs) ROHS; Manufacturer: Espressif Systems; Max Supply Voltage: 3.6V; Min Supply Voltage: 3V; Operating Supply Voltage: 3.3V; Category: System on Chip (SoC); Component Kind: Standard; ComponentLink1Description: https://datasheet.octopart.com:443/ESP32-C3-Espressif-Systems-datasheet-156944166.pdf?src-supplier=IHS; ComponentLink1URL: https://datasheet.octopart.com:443/ESP32-C3-Espressif-Systems-datasheet-156944166.pdf?src-supplier=IHS; ComponentLink2Description: https://datasheet.octopart.com:443/ESP32-C3-Espressif-Systems-datasheet-188867343.pdf?src-supplier=TME; ComponentLink2URL: https://datasheet.octopart.com:443/ESP32-C3-Espressif-Systems-datasheet-188867343.pdf?src-supplier=TME; ComponentLink3Description: https://datasheet.octopart.com:443/ESP32-C3-Espressif-Systems-datasheet-165560559.pdf?src-supplier=TME; ComponentLink3URL: https://datasheet.octopart.com:443/ESP32-C3-Espressif-Systems-datasheet-165560559.pdf?src-supplier=TME; Height - Seated (Max): 900µm; LCSC: C2838500; Length: 5mm; Library Name: Schlib_ESP32C3.SchLib; Manufacturer Part Number: ESP32-C3; Max Operating Temperature: 105°C; Min Operating Temperature: -40°C; Number of Terminals: 32; Pricing: 1=1 (USD); Stock: 2432; Supplier: DigiKey; Supplier Part Number: 1904-ESP32-C3CT-ND; Terminal Pitch: 500µm; Width: 5mm
U3 = SPX3819M5-L-3-3/TR: SPX3819 Series 3.3 V 500 mA Fixed Output SMT LDO Regulator - SOT-23-5; Manufacturer: MaxLinear; Accuracy: 1%; Dropout Voltage: 340mV; Max Junction Temperature (Tj): 125°C; Max Output current: 500mA; Max Supply Voltage: 16V; Min Input Voltage: 2.5V; Min Output Voltage: 3.3V; Number of Channels: 1; Polarity: Positive; Quiescent Current: 3µA; Case/Package: SOT-23-5; Category: LDO Voltage Regulators; Component Kind: Standard; ComponentLink1Description: https://datasheet.octopart.com:443/SPX3819M5-L-3-3-TR-MaxLinear-datasheet-164567055.pdf?src-supplier=ODG+(Origin+Data+Global); ComponentLink1URL: https://datasheet.octopart.com:443/SPX3819M5-L-3-3-TR-MaxLinear-datasheet-164567055.pdf?src-supplier=ODG+(Origin+Data+Global); ComponentLink2Description: https://datasheet.octopart.com:443/SPX3819M5-L-3-3-TR-MaxLinear-datasheet-68096079.pdf?src-supplier=IHS; ComponentLink2URL: https://datasheet.octopart.com:443/SPX3819M5-L-3-3-TR-MaxLinear-datasheet-68096079.pdf?src-supplier=IHS; ComponentLink3Description: https://datasheet.octopart.com:443/SPX3819M5-L-3-3-TR-MaxLinear-datasheet-136412968.pdf?src-supplier=LKR; ComponentLink3URL: https://datasheet.octopart.com:443/SPX3819M5-L-3-3-TR-MaxLinear-datasheet-136412968.pdf?src-supplier=LKR; ComponentLink4Description: https://datasheet.octopart.com:443/SPX3819M5-L-3-3-TR-Exar-datasheet-27092020.pdf?src-supplier=RS+(Formerly+Allied+Electronics); ComponentLink4URL: https://datasheet.octopart.com:443/SPX3819M5-L-3-3-TR-Exar-datasheet-27092020.pdf?src-supplier=RS+(Formerly+Allied+Electronics); ComponentLink5Description: https://datasheet.octopart.com:443/SPX3819M5-L-3-3/TR-Exar-datasheet-12510291.pdf?src-supplier=Future+Electronics; ComponentLink5URL: https://datasheet.octopart.com:443/SPX3819M5-L-3-3/TR-Exar-datasheet-12510291.pdf?src-supplier=Future+Electronics; ComponentLink6Description: https://datasheet.octopart.com:443/SPX3819M5-L-3-3/TR-Exar-datasheet-530831.pdf?src-supplier=Future+Electronics; ComponentLink6URL: https://datasheet.octopart.com:443/SPX3819M5-L-3-3/TR-Exar-datasheet-530831.pdf?src-supplier=Future+Electronics; ComponentLink7Description: https://datasheet.octopart.com:443/SPX3819M5-L-3-3-TR-Exar-datasheet-13046336.pdf?src-supplier=Future+Electronics; ComponentLink7URL: https://datasheet.octopart.com:443/SPX3819M5-L-3-3-TR-Exar-datasheet-13046336.pdf?src-supplier=Future+Electronics; ComponentLink8Description: https://datasheet.octopart.com:443/SPX3819M5-L-3-3/TR-Exar-datasheet-14171829.pdf?src-supplier=iiiC; ComponentLink8URL: https://datasheet.octopart.com:443/SPX3819M5-L-3-3/TR-Exar-datasheet-14171829.pdf?src-supplier=iiiC; Height: 1.45mm; Height - Seated (Max): 1.45mm; LCSC: C9055; Lead Free: Lead Free; Length: 2.9mm; Library Name: Schlib_ESP32C3.SchLib; Lifecycle Status: Production; Manufacturer Part Number: SPX3819M5-L-3-3/TR; Max Operating Temperature: 125°C; Min Operating Temperature: -40°C; Min Supply Voltage: 2.5V; Mounting Technology: Surface Mount; Number of Circuits: 1; Number of Outputs: 1; Number of Regulators: 1; Number of Terminals: 5; Output Current: 500mA; Output Type: Fixed; Output Voltage: 3.3V; Output Voltage Accuracy: 1%; Packaging: Tape and Reel; Pins: 5; Pricing: 1=0.44, 10=0.306, 25=0.2724, 100=0.2361, 250=0.21876, 500=0.2083, 1000=0.19968 (USD); Radiation Hardening: No; REACH SVHC: Yes; RoHS: Compliant; Schedule B: 8542310000, 8542310000|8542310000|8542310000|8542310000|8542310000; Stock: 67889; Supplier: DigiKey; Supplier Part Number: 1016-1873-6-ND; Terminal Pitch: 950µm; Voltage Tolerance: 1%; Width: 1.6mm
U4 = BMI055: LGA-16(3x4.5) Accelerometers RoHS; Manufacturer: Bosch; Component Kind: Standard; LCSC: C189620; Library Name: Schlib_ESP32C3.SchLib; Manufacturer Part Number: BMI055
X1 = XTAL_40MHz_2520: Crystal 40MHz ±10ppm (Tol) ±20ppm (Stability) 9pF FUND 40Ohm 4-Pin Ultra Mini-CSMD T/R; Manufacturer: Epson; Manufacturer Part Number: FA-20H 40.0000MF20X-AC3; ESR: 40Ω; Frequency Tolerance: 0.001%; Case/Package: SMD/SMT; Category: Crystals; China RoHS: Compliant; Component Kind: Standard; ComponentLink1Description: https://datasheet.octopart.com:443/FA-20H-40.0000MF20X-AC3-Epson-datasheet-62043847.pdf?src-supplier=IHS; ComponentLink1URL: https://datasheet.octopart.com:443/FA-20H-40.0000MF20X-AC3-Epson-datasheet-62043847.pdf?src-supplier=IHS; ComponentLink2Description: https://datasheet.octopart.com:443/FA-20H40.0000MF20X-AC3-Epson-datasheet-189100293.pdf?src-supplier=Electro+Sonic; ComponentLink2URL: https://datasheet.octopart.com:443/FA-20H40.0000MF20X-AC3-Epson-datasheet-189100293.pdf?src-supplier=Electro+Sonic; ComponentLink3Description: http://sigma.octopart.com:80/149134829/reach_statement/Epson-FA-20H-40.0000MF20X-AC3.pdf?src-supplier=Compliance+Statements; ComponentLink3URL: http://sigma.octopart.com:80/149134829/reach_statement/Epson-FA-20H-40.0000MF20X-AC3.pdf?src-supplier=Compliance+Statements; ComponentLink4Description: http://sigma.octopart.com:80/149134830/rohs_statement/Epson-FA-20H-40.0000MF20X-AC3.pdf?src-supplier=Compliance+Statements; ComponentLink4URL: http://sigma.octopart.com:80/149134830/rohs_statement/Epson-FA-20H-40.0000MF20X-AC3.pdf?src-supplier=Compliance+Statements; Contact Plating: Gold; ESR (Equivalent Series Resistance): 40Ω; Frequency: 40MHz; Frequency Stability: 20ppm; Height: 558.8µm; LCSC: C255973; Length: 2.4892mm; Library Name: Schlib_ESP32C3.SchLib; Load Capacitance: 9pF; Max Operating Temperature: 85°C; Min Operating Temperature: -40°C; Mounting Technology: Surface Mount; Packaging: Bulk; Pricing: 250=0.98172 (USD); REACH SVHC: Yes; RoHS: Compliant; Supplier: DigiKey; Supplier Part Number: FA-20H40.0000MF20X-AC3-ND; Width: 2.0066mm
